# Altium schematic sheet TP1.SchDoc
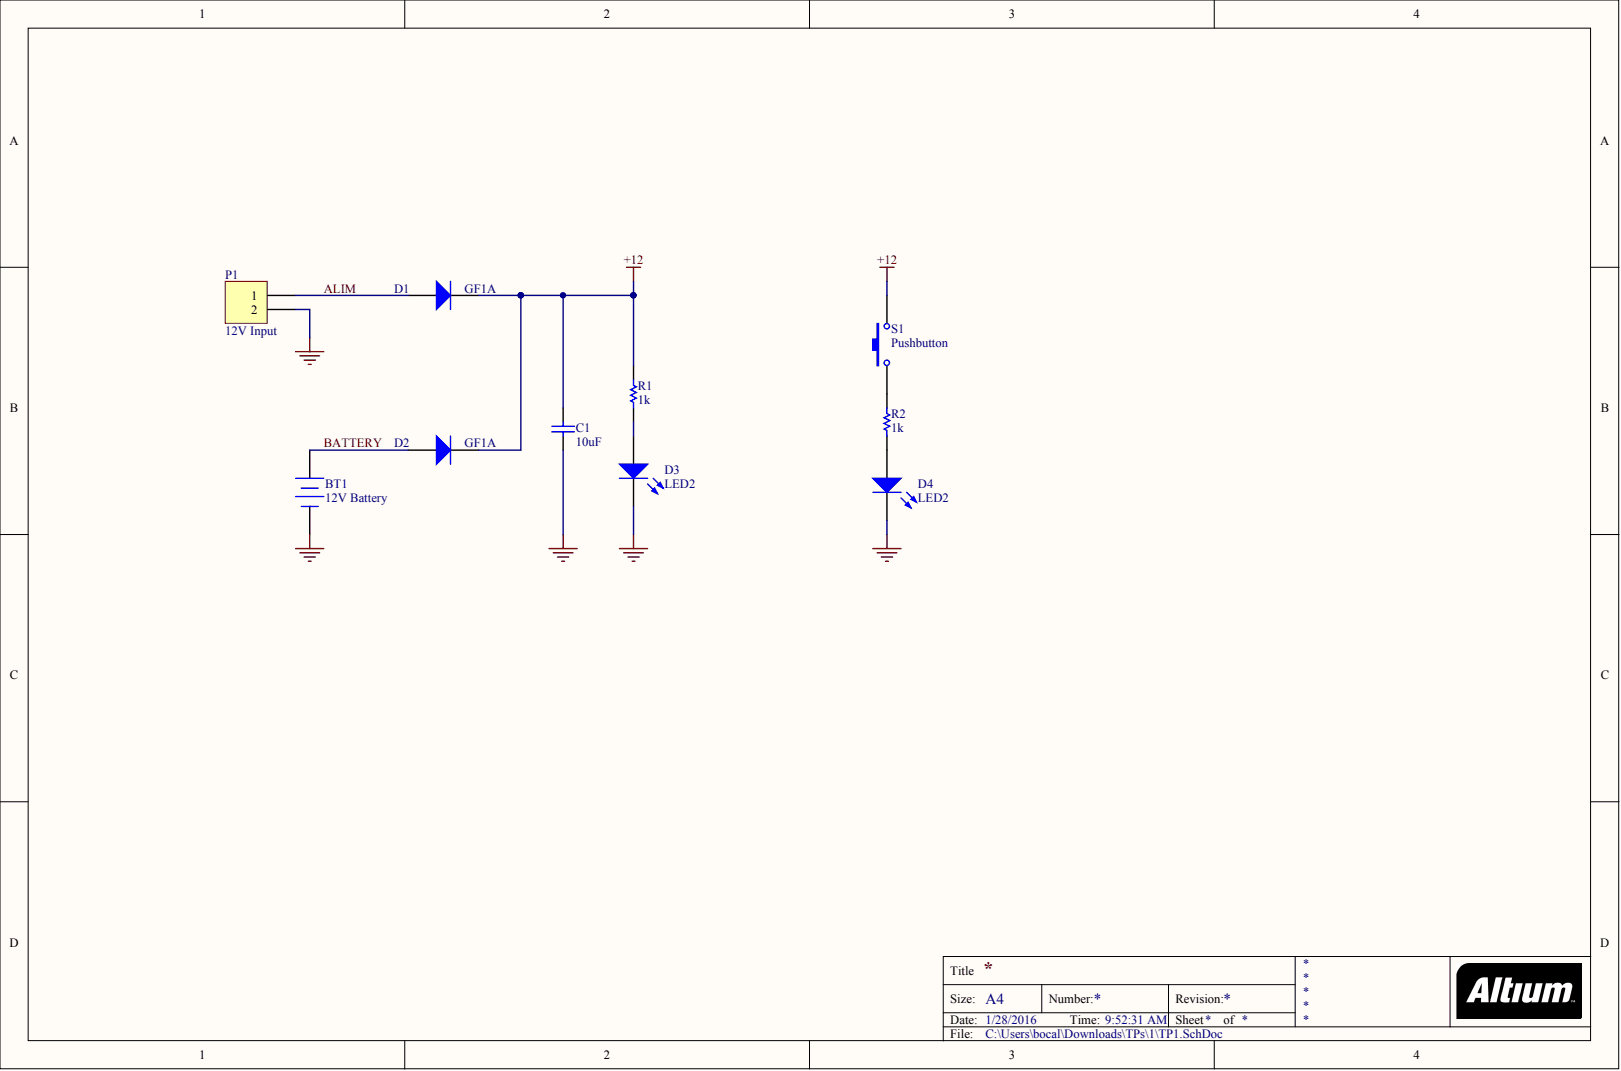
[diagram p0: TP1.SchDoc]

## Parts
BT1  12V Battery  [BAT-2]
C1  10uF  [C1206]
D1  GF1A  [SMC]
D2  GF1A  [SMC]
D3  LED2  [3.2X1.6X1.1]
D4  LED2  [3.2X1.6X1.1]
P1  12V Input  [HDR1X2]
R1  1k  [AXIAL-0.3]
R2  1k  [AXIAL-0.3]
S1  Pushbutton  [SPST-2]

## Nets
+12: C1.2 D1.2 D2.2 R1.2 S1.2
GND: BT1.2 C1.1 D3.2 D4.2 P1.2
ALIM: D1.1 P1.1
BATTERY: BT1.1 D2.1
NetD3_1: D3.1 R1.1
NetD4_1: D4.1 R2.1
NetR2_2: R2.2 S1.1

## Library data of the parts
BT1 = Battery: Multicell Battery; Component Kind: Standard; Library Name: Miscellaneous Devices.IntLib
C1 = Cap Semi: Capacitor (Semiconductor SIM Model); Value: 100pF; Component Kind: Standard; Library Name: Miscellaneous Devices.IntLib; Signal Integrity: Cap Semi; Simulation: CAP
D1 D2 = Diode: Default Diode; Component Kind: Standard; Library Name: Miscellaneous Devices.IntLib; Signal Integrity: Diode; Simulation: DIODE
D3 D4 = LED2: Typical RED, GREEN, YELLOW, AMBER GaAs LED; Component Kind: Standard; Library Name: Miscellaneous Devices.IntLib; Simulation: LED2
P1 = Header 2: Header, 2-Pin; Component Kind: Standard; Library Name: Miscellaneous Connectors.IntLib; Signal Integrity: Connector
R1 R2 = Res1: Resistor; Component Kind: Standard; Library Name: Miscellaneous Devices.IntLib; Signal Integrity: Res; Simulation: RESISTOR; Value: 1K
S1 = SW-PB: Switch; Component Kind: Standard; Library Name: Miscellaneous Devices.IntLib
